# Altium schematic sheet Power.SchDoc(Power)
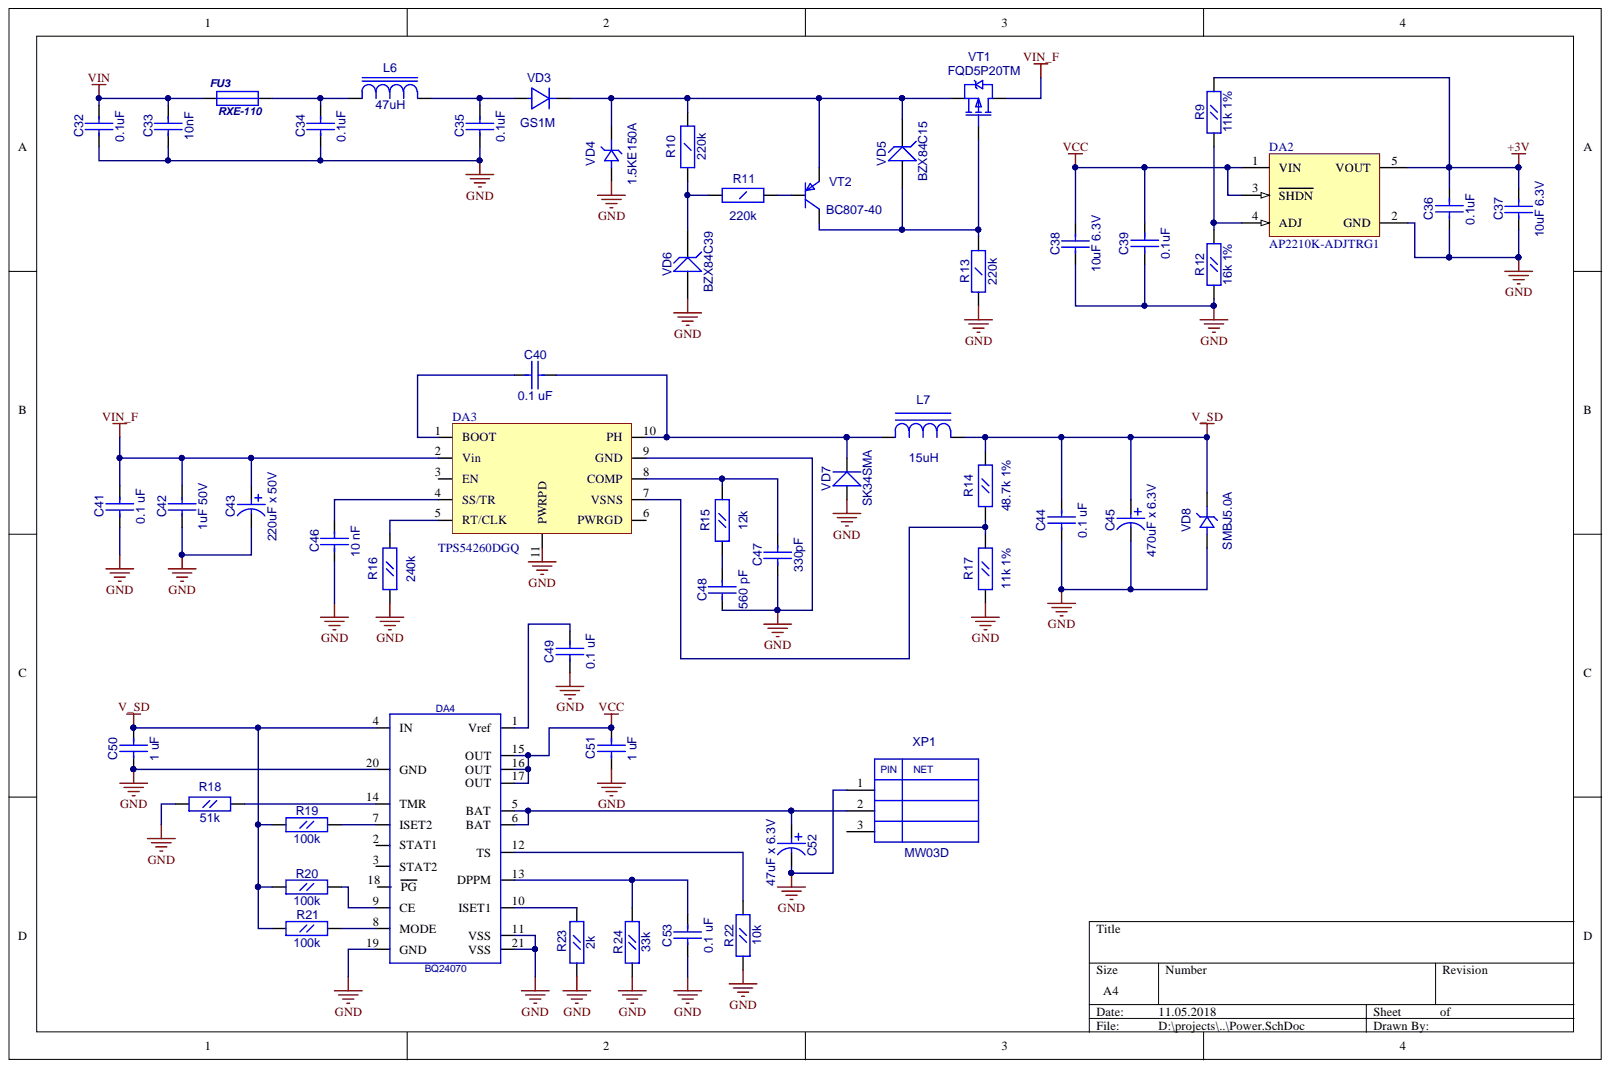
[diagram p0: Power.SchDoc]

## Parts
C32  0.1uF  [CC1206]
C33  10nF  [CC1206]
C34  0.1uF  [CC1206]
C35  0.1uF  [CC1206]
C36  0.1uF  [CC0603]
C37  10uF 6.3V  [CC0805]
C38  10uF 6.3V  [CC0805]
C39  0.1uF  [CC0603]
C40  0.1 uF  [CC0603]
C41  0.1 uF  [CC0603]
C42  1uF 50V  [CC1206]
C43  220uF x 50V  [CC16X10_CAP0.5(1)(Primary)]
C44  0.1 uF  [CC0603]
C45  470uF x 6.3V  [TAJ-D-1M]
C46  10 nF  [CC0603]
C47  330pF  [CC0603]
C48  560 pF  [CC0603]
C49  0.1 uF  [CC0603]
C50  1 uF  [CC0603]
C51  1 uF  [CC0603]
C52  47uF x 6.3V  [TAJ-A_M]
C53  0.1 uF  [CC0603]
DA2  AP2210K-ADJTRG1  [SOT23-5]
DA3  TPS54260DGQ  [S-PDSO-G10]
DA4  BQ24070  [P-PQFP-N20]
FU3  RXE-110  [RXE-110]
L6  47uH  [SDR0603]
L7  15uH  [SDR0805]
R9  11k 1%  [RC0603]
R10  220k  [RC1206]
R11  220k  [RC1206]
R12  16k 1%  [RC0603]
R13  220k  [RC1206]
R14  48.7k 1%  [RC0603]
R15  12k  [RC0603]
R16  240k  [RC0603]
R17  11k 1%  [RC0603]
R18  51k  [RC0603]
R19  100k  [RC0603]
R20  100k  [RC0603]
R21  100k  [RC0603]
R22  10k  [RC0603]
R23  2k  [RC0603]
R24  33k  [RC0603]
VD3  GS1M  [SMA]
VD4  1.5KE150A  [DO-201]
VD5  BZX84C15  [SOT-23(123)]
VD6  BZX84C39  [SOT-23(123)]
VD7  SK34SMA  [SMA]
VD8  SMBJ5.0A  [SMB]
VT1  FQD5P20TM  [D-PAK(TO-252AA)]
VT2  BC807-40  [SOT-23(123)]
XP1  MW03D  [MW03D]

## Nets
+3V: C36.1 C37.2 DA2.5(VOUT) R9.2
GND: C32.1 C33.1 C34.1 C35.1 C36.2 C37.1 C38.1 C39.2 C41.1 C42.1 C43.1 C44.2 C45.2 C46.1 C47.1 C48.1 C49.2 C50.2 C51.2 C52.2 C53.2 DA2.2(GND) DA3.9(GND) DA3.11(PWRPD) DA4.11(VSS) DA4.19(GND) DA4.20(GND) DA4.21(VSS) R12.1 R13.2 R16.1 R17.1 R18.1 R22.1 R23.1 R24.1 VD4.1 VD6.1 VD7.1 VD8.1 XP1.1
V_SD: C44.1 C45.1 C50.1 DA4.4(IN) L7.2 R14.2 R19.1 R20.1 R21.1 VD8.2
VCC: C38.2 C39.1 C51.1 DA2.1(VIN) DA2.3(SHDN) DA4.15(OUT) DA4.16(OUT) DA4.17(OUT)
VIN: C32.2 C33.2 FU3.1
VIN_F: C41.2 C42.2 C43.2 DA3.2(Vin) VT1.3
NetC34_2: C34.2 FU3.2 L6.1
NetC35_2: C35.2 L6.2 VD3.1
NetC40_1: C40.1 DA3.1(BOOT)
NetC40_2: C40.2 DA3.10(PH) L7.1 VD7.2
NetC46_2: C46.2 DA3.4(SS/TR)
NetC47_2: C47.2 DA3.8(COMP) R15.2
NetC48_2: C48.2 R15.1
NetC49_1: C49.1 DA4.1(Vref)
NetC52_1: C52.1 DA4.5(BAT) DA4.6(BAT) XP1.2
NetC53_1: C53.1 DA4.13(DPPM) R24.2
NetDA2_4: DA2.4(ADJ) R9.1 R12.2
NetDA3_5: DA3.5(RT/CLK) R16.2
NetDA3_7: DA3.7(VSNS) R14.1 R17.2
NetDA4_7: DA4.7(ISET2) R19.2
NetDA4_8: DA4.8(MODE) R21.2
NetDA4_9: DA4.9(CE) R20.2
NetDA4_10: DA4.10(ISET1) R23.2
NetDA4_12: DA4.12(TS) R22.2
NetDA4_14: DA4.14(TMR) R18.2
NetR10_1: R10.1 R11.1 VD6.3
NetR10_2: R10.2 VD3.2 VD4.2 VD5.3 VT1.2 VT2.2
NetR11_2: R11.2 VT2.1
NetR13_1: R13.1 VD5.1 VT1.1 VT2.3

Not connected: DA3 3(EN) 6(PWRGD); DA4 2(STAT1) 3(STAT2) 18(PG); XP1 3

## Library data of the parts
C32-C35 C42 = CC1206: Description: CC1206; Component Kind: Standard; Library Name: gprs_modem_2.SchLib
  C32 C34 C35: Value: 0.1uF
  C33: Value: 10nF
  C42: Value: 1uF 50V
C36 C39-C41 C44 C46-C51 C53 = CC0603: Description: CC0603; Component Kind: Standard
  C36 C39: Value: 0.1uF
  C40 C41 C44 C49 C53: Value: 0.1 uF
  C46: Value: 10 nF
  C47: Value: 330pF
  C48: Value: 560 pF
  C50 C51: Value: 1 uF
  C36 C39-C41 C46-C50 C53: Library Name: gprs_modem_2.SchLib
C37 C38 = CC0805: Value: 10uF 6.3V; Description: CC0805; Component Kind: Standard; Library Name: gprs_modem_2.SchLib
C43 = CAP0.5(1): Value: 220uF x 50V; Description: CAP0.5(1); Component Kind: Standard; Library Name: gprs_modem.SchLib
C45 = TAJ-D: Value: 470uF x 6.3V; Description: TAJ-D; Component Kind: Standard; Library Name: gprs_modem.SchLib
C52 = TAJ-A: Value: {Value}; Description: TAJ-A; Component Kind: Standard; Library Name: gprs_modem_2.SchLib
DA2 = MCP1824T-ADJE/OT: 300 mA, Low Voltage, Low Quiescent Current LDO Regulator, 5-Pin SOT-223, Extended Temperature, Tape and Reel; Published: 29-Jan-2009; PackageVersion: revAW, Oct-2008; PackageReference: SOT-223-DC5; PackageDescription: 5-Lead Plastic Small Outline Transistor (DC) [SOT-223]; DatasheetVersion: revA, Aug-2007; ComponentLink3URL: http://ww1.microchip.com/downloads/en/PackagingSpec/00049AW.pdf; ComponentLink3Description: Packaging Specification; ComponentLink2URL: http://ww1.microchip.com/downloads/en/DeviceDoc/22070a.pdf; ComponentLink2Description: Datasheet; ComponentLink1URL: http://www.microchip.com; ComponentLink1Description: Manufacturer Link; Component Kind: Standard; Publisher: Altium Limited; Library Name: gprs_modem_2.SchLib
L6 = SDR0603: Value: 47uH; Description: SDR0603; Component Kind: Standard; Library Name: gprs_modem_2.SchLib
L7 = SDR0805: Value: 15uH; Description: SDR0805; Component Kind: Standard; Library Name: gprs_modem.SchLib
R9 R12 R14-R17 R19-R24 = RC0603: Description: RC0603; Component Kind: Standard
  R9 R17: Value: 11k 1%
  R12: Value: 16k 1%
  R14: Value: 48.7k 1%
  R15: Value: 12k
  R16: Value: 240k
  R19-R21: Value: 100k
  R22: Value: 10k
  R23: Value: 2k
  R24: Value: 33k
  R9 R12 R14-R17 R19 R20: Library Name: gprs_modem_2.SchLib
R10 R11 R13 = RC1206: Value: 220k; Description: RC1206; Component Kind: Standard; Library Name: gprs_modem_2.SchLib
R18 = RC0603: Value: {Value}; Description: RC0603; Component Kind: Standard; Library Name: gprs_modem_2.SchLib
VD3 = GS1M: Value: {Value}; Description: GS1M; Component Kind: Standard; Library Name: gprs_modem.SchLib
VD4 = 1.5KE: Value: 1.5KE150A; Description: 1.5KE; Component Kind: Standard; Library Name: gprs_modem.SchLib
VD5 VD6 = BZX84: Zener Voltage Regulator Diode; Value: {Value}; Component Kind: Standard; Library Name: gprs_modem_2.SchLib
VD7 = SK34SMA: Schottky Rectifier; Value: {Value}; Component Kind: Standard; Library Name: gprs_modem.SchLib
VD8 = SMBJ: Description: SMBJ; Component Kind: Standard; Library Name: gprs_modem.SchLib
VT1 = IRFR9220: HEXFET Power MOSFET; OriginalName: IRLML5103; ComponentField7: Published 8-Jun-2000; Value: FQD5P20TM; Component Kind: Standard; Library Name: gprs_modem_2.SchLib
VT2 = BC857B: OriginalName: BC846BLT1; ComponentField7: Published 29-Sep-2000; Value: BC807-40; Simulation: BC846BLT1; Description: BC857B; Component Kind: Standard; Library Name: gprs_modem.SchLib
DA3 = TPS54260DGQ: Component Kind: Standard; Library Name: gprs_modem.SchLib
DA4 = BQ24070: Description: BQ24070; Component Kind: Standard; Library Name: gprs_modem.SchLib
FU3 = RXE-110: Description: RXE-110; Component Kind: Standard; Library Name: gprs_modem.SchLib
XP1 = MW03D: Description: MW03D; Component Kind: Standard; Library Name: gprs_modem.SchLib
